# Altium schematic sheet MCU.SchDoc
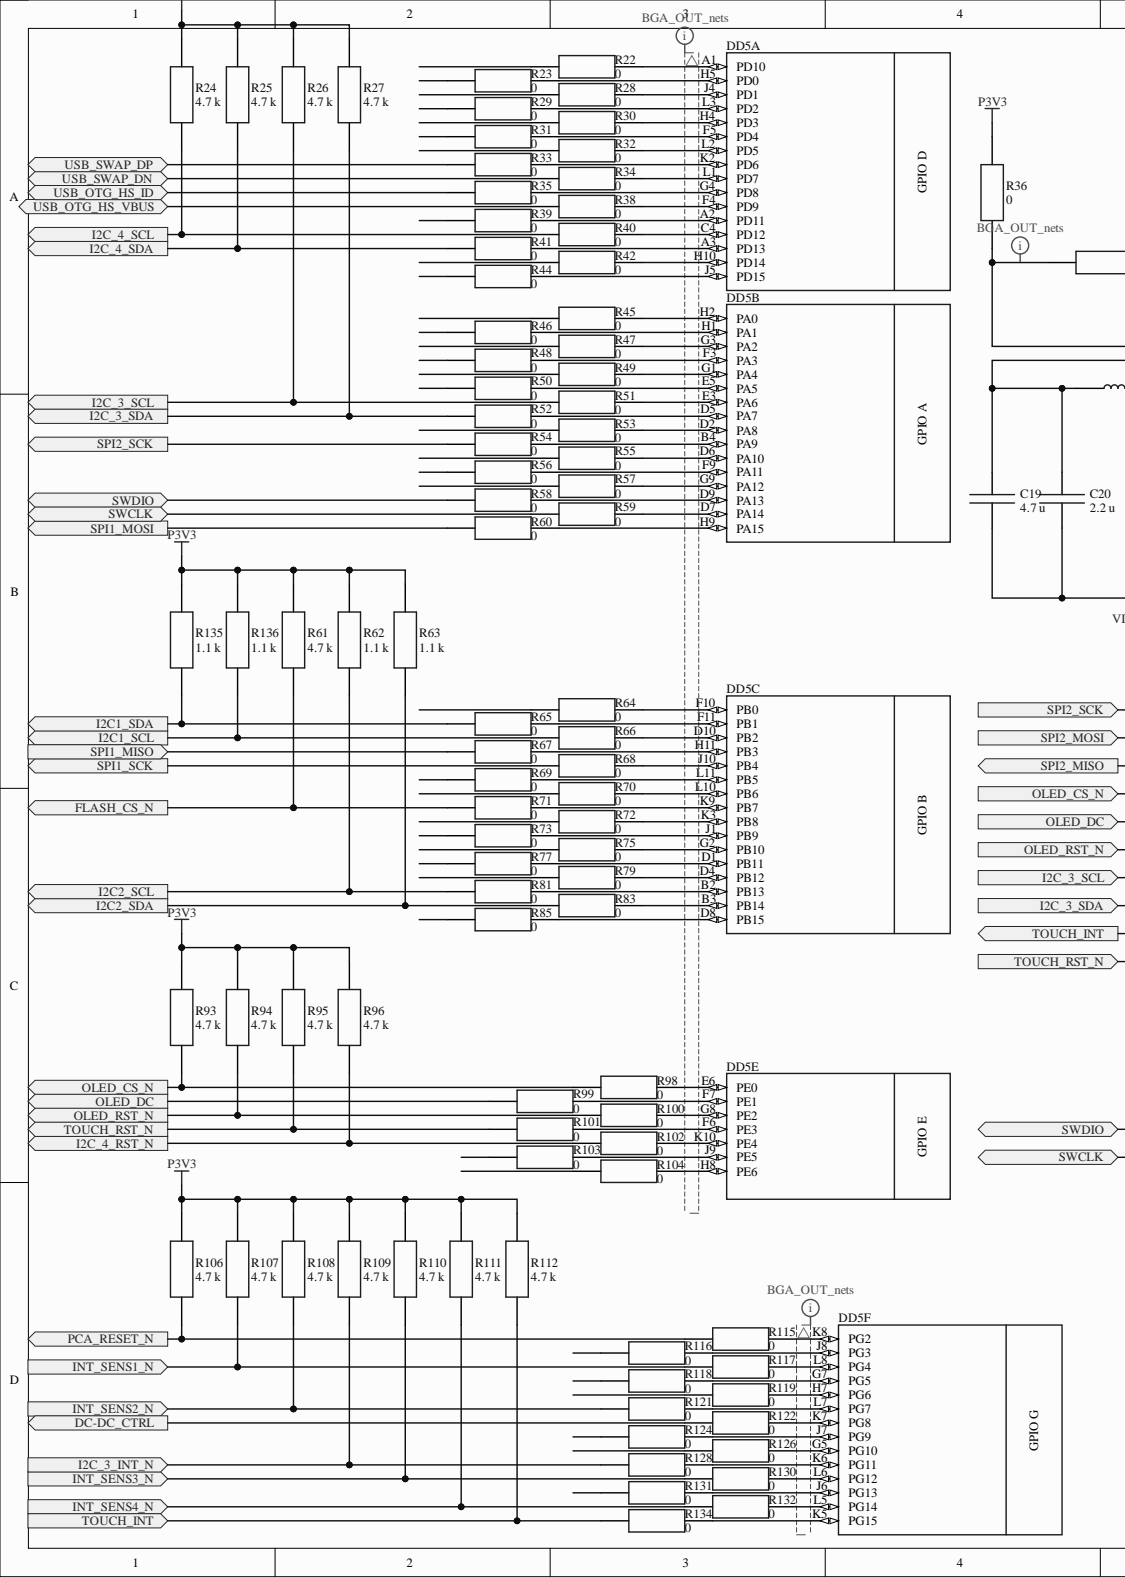
[diagram p0: MCU.SchDoc, left]
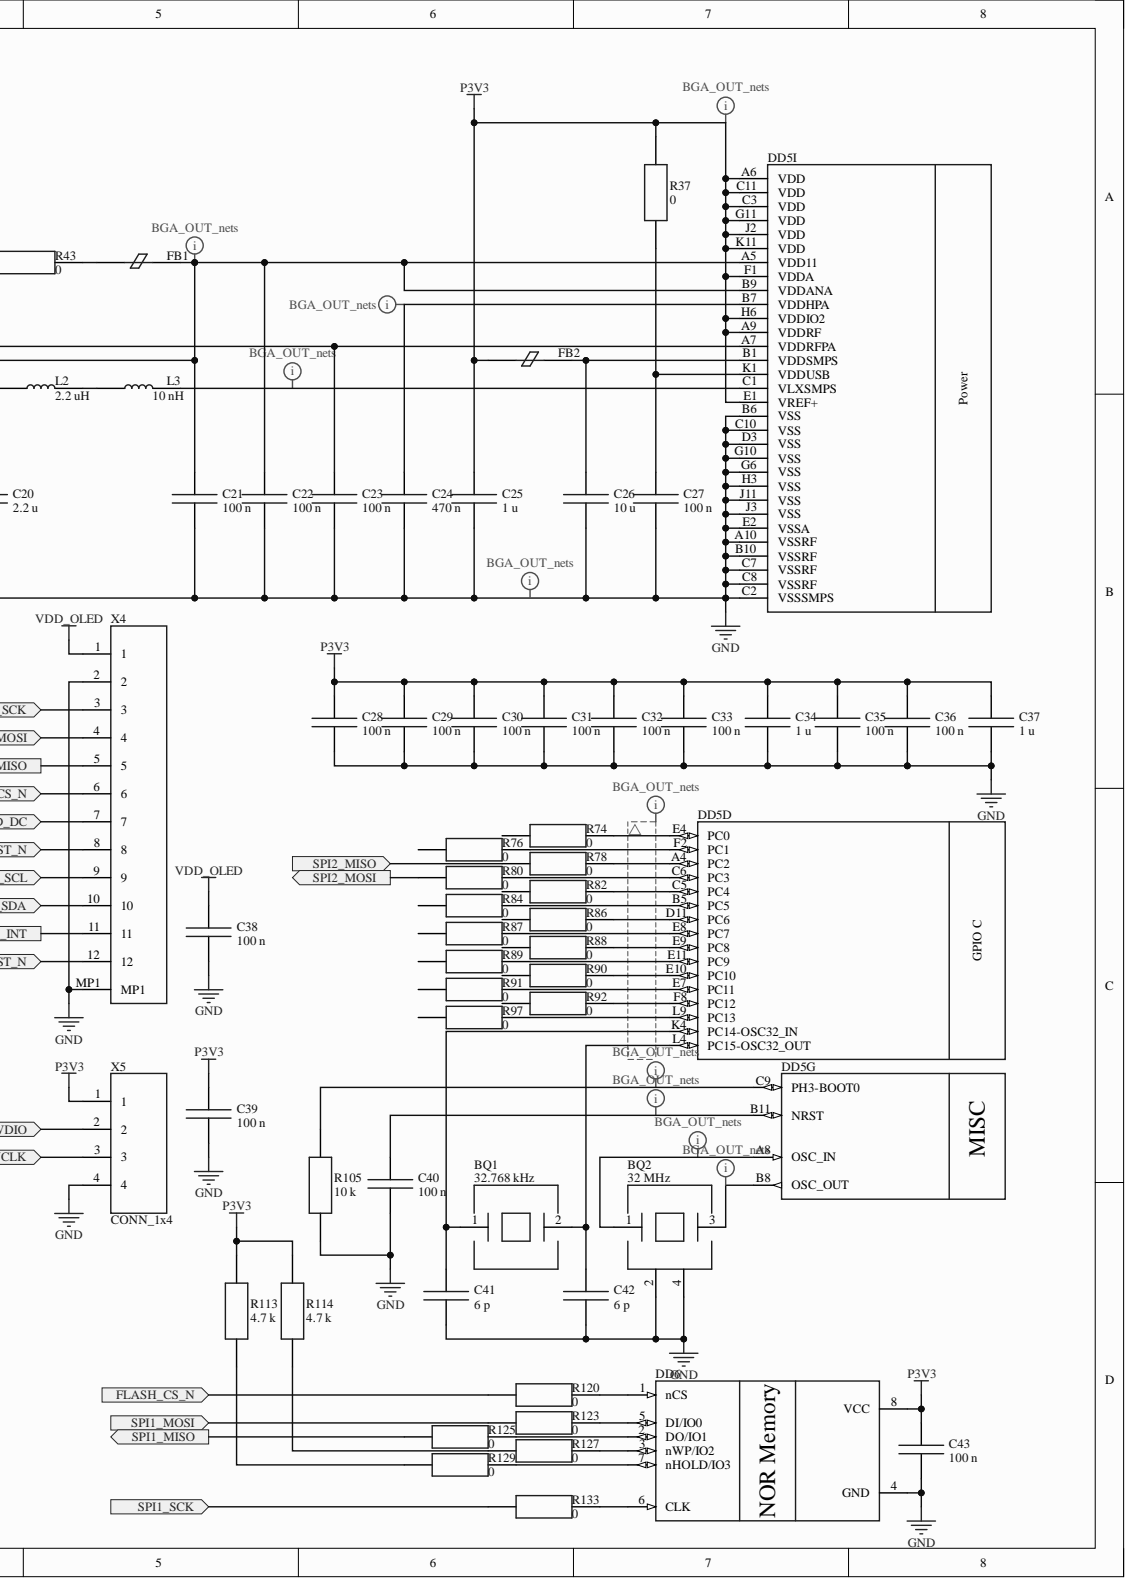
[diagram p1: MCU.SchDoc, right]

## Parts
BQ1  32.768 kHz  [BQ_SMD1610-2P]
BQ2  32 MHz  [BQ_SMD1612-4P]
C19  4.7 u  [C0402]
C20  2.2 u  [C0402]
C21  100 n  [C0402]
C22  100 n  [C0402]
C23  100 n  [C0402]
C24  470 n  [C0402]
C25  1 u  [C0402]
C26  10 u  [C0603]
C27  100 n  [C0402]
C28  100 n  [C0402]
C29  100 n  [C0402]
C30  100 n  [C0402]
C31  100 n  [C0402]
C32  100 n  [C0402]
C33  100 n  [C0402]
C34  1 u  [C0402]
C35  100 n  [C0402]
C36  100 n  [C0402]
C37  1 u  [C0402]
C38  100 n  [C0402]
C39  100 n  [C0402]
C40  100 n  [C0402]
C41  6 p  [C0402]
C42  6 p  [C0402]
C43  100 n  [C0402]
DD5  STM32WBA65PII6  [UFBGA-121_6x6_P0.5]  (value not drawn)  (parts A,B,C,D,E,F,G,I)
DD6  W25Q64JVSSIQ  [SOIC-8_5.28x5.28_P1.27]  (value not drawn)
FB1  (no value)  [FB0603]
FB2  (no value)  [FB0603]
L2  2.2 uH  [IND0805]
L3  10 nH  [IND0402]
R22  0  [R0402]
R23  0  [R0402]
R24  4.7 k  [R0402]
R25  4.7 k  [R0402]
R26  4.7 k  [R0402]
R27  4.7 k  [R0402]
R28  0  [R0402]
R29  0  [R0402]
R30  0  [R0402]
R31  0  [R0402]
R32  0  [R0402]
R33  0  [R0402]
R34  0  [R0402]
R35  0  [R0402]
R36  0  [R0402]
R37  0  [R0402]
R38  0  [R0402]
R39  0  [R0402]
R40  0  [R0402]
R41  0  [R0402]
R42  0  [R0402]
R43  0  [R0402]
R44  0  [R0402]
R45  0  [R0402]
R46  0  [R0402]
R47  0  [R0402]
R48  0  [R0402]
R49  0  [R0402]
R50  0  [R0402]
R51  0  [R0402]
R52  0  [R0402]
R53  0  [R0402]
R54  0  [R0402]
R55  0  [R0402]
R56  0  [R0402]
R57  0  [R0402]
R58  0  [R0402]
R59  0  [R0402]
R60  0  [R0402]
R61  4.7 k  [R0402]
R62  1.1 k  [R0402]
R63  1.1 k  [R0402]
R64  0  [R0402]
R65  0  [R0402]
R66  0  [R0402]
R67  0  [R0402]
R68  0  [R0402]
R69  0  [R0402]
R70  0  [R0402]
R71  0  [R0402]
R72  0  [R0402]
R73  0  [R0402]
R74  0  [R0402]
R75  0  [R0402]
R76  0  [R0402]
R77  0  [R0402]
R78  0  [R0402]
R79  0  [R0402]
R80  0  [R0402]
R81  0  [R0402]
R82  0  [R0402]
R83  0  [R0402]
R84  0  [R0402]
R85  0  [R0402]
R86  0  [R0402]
R87  0  [R0402]
R88  0  [R0402]
R89  0  [R0402]
R90  0  [R0402]
R91  0  [R0402]
R92  0  [R0402]
R93  4.7 k  [R0402]
R94  4.7 k  [R0402]
R95  4.7 k  [R0402]
R96  4.7 k  [R0402]
R97  0  [R0402]
R98  0  [R0402]
R99  0  [R0402]
R100  0  [R0402]
R101  0  [R0402]
R102  0  [R0402]
R103  0  [R0402]
R104  0  [R0402]
R105  10 k  [R0402]
R106  4.7 k  [R0402]
R107  4.7 k  [R0402]
R108  4.7 k  [R0402]
R109  4.7 k  [R0402]
R110  4.7 k  [R0402]
R111  4.7 k  [R0402]
R112  4.7 k  [R0402]
R113  4.7 k  [R0402]
R114  4.7 k  [R0402]
R115  0  [R0402]
R116  0  [R0402]
R117  0  [R0402]
R118  0  [R0402]
R119  0  [R0402]
R120  0  [R0402]
R121  0  [R0402]
R122  0  [R0402]
R123  0  [R0402]
R124  0  [R0402]
R125  0  [R0402]
R126  0  [R0402]
R127  0  [R0402]
R128  0  [R0402]
R129  0  [R0402]
R130  0  [R0402]
R131  0  [R0402]
R132  0  [R0402]
R133  0  [R0402]
R134  0  [R0402]
R135  1.1 k  [R0402]
R136  1.1 k  [R0402]
X4  SM12B-GHS-TB(LF)(SN)  [JST_SM12B-GHS-TB_LF_SN]  (value not drawn)
X5  CONN_1x4  [DS1021-1x4]

## Ports
DC-DC_CTRL, FLASH_CS_N, I2C1_SCL, I2C1_SDA, I2C2_SCL, I2C2_SDA, I2C_3_INT_N, I2C_3_SCL, I2C_3_SDA, I2C_4_RST_N, I2C_4_SCL, I2C_4_SDA, INT_SENS1_N, INT_SENS2_N, INT_SENS3_N, INT_SENS4_N, OLED_CS_N, OLED_DC, OLED_RST_N, PCA_RESET_N, SPI1_MISO, SPI1_MOSI, SPI1_SCK, SPI2_MISO, SPI2_MOSI, SPI2_SCK, SWCLK, SWDIO, TOUCH_INT, TOUCH_RST_N, USB_OTG_HS_ID, USB_OTG_HS_VBUS, USB_SWAP_DN, USB_SWAP_DP

## Nets
GND: BQ2.2 BQ2.4 C19.2 C20.2 C21.2 C22.2 C23.2 C24.2 C25.2 C26.2 C27.2 C28.2 C29.2 C30.2 C31.2 C32.2 C33.2 C34.2 C35.2 C36.2 C37.2 C38.2 C39.2 C40.2 C41.2 C42.2 C43.2 DD5.A10(VSSRF) DD5.B6(VSS) DD5.B10(VSSRF) DD5.C2(VSSSMPS) DD5.C7(VSSRF) DD5.C8(VSSRF) DD5.C10(VSS) DD5.D3(VSS) DD5.E2(VSSA) DD5.G6(VSS) DD5.G10(VSS) DD5.H3(VSS) DD5.J3(VSS) DD5.J11(VSS) DD6.4(GND) R105.1 X4.2 X4.MP1 X5.4
P3V3: C25.1 C28.1 C29.1 C30.1 C31.1 C32.1 C33.1 C34.1 C35.1 C36.1 C37.1 C39.1 C43.1 DD5.A6(VDD) DD5.A9(VDDRF) DD5.C3(VDD) DD5.C11(VDD) DD5.E1(VREF+) DD5.F1(VDDA) DD5.G11(VDD) DD5.H6(VDDIO2) DD5.J2(VDD) DD5.K11(VDD) DD6.8(VCC) FB2.1 R24.2 R25.2 R26.2 R27.2 R36.2 R37.2 R61.2 R62.2 R63.2 R93.2 R94.2 R95.2 R96.2 R106.2 R107.2 R108.2 R109.2 R110.2 R111.2 R112.2 R113.2 R114.2 R135.2 R136.2 X5.1
VDD_OLED: C38.1 X4.1
DC-DC_CTRL: R122.1 ; port DC-DC_CTRL
FLASH_CS_N: R61.1 R71.1 R120.1 ; port FLASH_CS_N
I2C1_SCL: R66.1 R136.1 ; port I2C1_SCL
I2C1_SDA: R65.1 R135.1 ; port I2C1_SDA
I2C2_SCL: R62.1 R81.1 ; port I2C2_SCL
I2C2_SDA: R63.1 R83.1 ; port I2C2_SDA
I2C_3_INT_N: R109.1 R128.1 ; port I2C_3_INT_N
I2C_3_SCL: R26.1 R51.1 X4.9 ; port I2C_3_SCL
I2C_3_SDA: R27.1 R52.1 X4.10 ; port I2C_3_SDA
I2C_4_RST_N: R96.1 R102.1 ; port I2C_4_RST_N
I2C_4_SCL: R24.1 R40.1 ; port I2C_4_SCL
I2C_4_SDA: R25.1 R41.1 ; port I2C_4_SDA
INT_SENS1_N: R107.1 R117.1 ; port INT_SENS1_N
INT_SENS2_N: R108.1 R121.1 ; port INT_SENS2_N
INT_SENS3_N: R110.1 R130.1 ; port INT_SENS3_N
INT_SENS4_N: R111.1 R132.1 ; port INT_SENS4_N
OLED_CS_N: R93.1 R98.1 X4.6 ; port OLED_CS_N
OLED_DC: R99.1 X4.7 ; port OLED_DC
OLED_RST_N: R94.1 R100.1 X4.8 ; port OLED_RST_N
PCA_RESET_N: R106.1 R115.1 ; port PCA_RESET_N
SPI1_MISO: R67.1 R125.1 ; port SPI1_MISO
SPI1_MOSI: R60.1 R123.1 ; port SPI1_MOSI
SPI1_SCK: R68.1 R133.1 ; port SPI1_SCK
SPI2_MISO: R78.1 X4.5 ; port SPI2_MISO
SPI2_MOSI: R80.1 X4.4 ; port SPI2_MOSI
SPI2_SCK: R54.1 X4.3 ; port SPI2_SCK
SWCLK: R59.1 X5.3 ; port SWCLK
SWDIO: R58.1 X5.2 ; port SWDIO
TOUCH_INT: R112.1 R134.1 X4.11 ; port TOUCH_INT
TOUCH_RST_N: R95.1 R101.1 X4.12 ; port TOUCH_RST_N
USB_OTG_HS_ID: R35.1 ; port USB_OTG_HS_ID
USB_OTG_HS_VBUS: R38.1 ; port USB_OTG_HS_VBUS
USB_SWAP_DN: R34.1 ; port USB_SWAP_DN
USB_SWAP_DP: R33.1 ; port USB_SWAP_DP
NetBQ1_1: BQ1.1 C41.1 DD5.K4(PC14-OSC32_IN)
NetBQ1_2: BQ1.2 C42.1 DD5.L4(PC15-OSC32_OUT)
NetBQ2_1: BQ2.1 DD5.A8(OSC_IN)
NetBQ2_3: BQ2.3 DD5.B8(OSC_OUT)
NetC19_1: C19.1 C20.1 C21.1 C22.1 DD5.A5(VDD11) DD5.B9(VDDANA) FB1.2 L2.1
NetC23_1: C23.1 DD5.A7(VDDRFPA) R36.1 R43.1
NetC24_1: C24.1 DD5.B7(VDDHPA)
NetC26_1: C26.1 DD5.B1(VDDSMPS) FB2.2
NetC27_1: C27.1 DD5.K1(VDDUSB) R37.1
NetC40_1: C40.1 DD5.B11(NRST)
NetDD5_A1: DD5.A1(PD10) R22.2
NetDD5_A2: DD5.A2(PD11) R39.2
NetDD5_A3: DD5.A3(PD13) R41.2
NetDD5_A4: DD5.A4(PC2) R78.2
NetDD5_B2: DD5.B2(PB13) R81.2
NetDD5_B3: DD5.B3(PB14) R83.2
NetDD5_B4: DD5.B4(PA9) R54.2
NetDD5_B5: DD5.B5(PC5) R84.2
NetDD5_C1: DD5.C1(VLXSMPS) L3.2
NetDD5_C4: DD5.C4(PD12) R40.2
NetDD5_C5: DD5.C5(PC4) R82.2
NetDD5_C6: DD5.C6(PC3) R80.2
NetDD5_C9: DD5.C9(PH3-BOOT0) R105.2
NetDD5_D1: DD5.D1(PB11) R77.2
NetDD5_D2: DD5.D2(PA8) R53.2
NetDD5_D4: DD5.D4(PB12) R79.2
NetDD5_D5: DD5.D5(PA7) R52.2
NetDD5_D6: DD5.D6(PA10) R55.2
NetDD5_D7: DD5.D7(PA14) R59.2
NetDD5_D8: DD5.D8(PB15) R85.2
NetDD5_D9: DD5.D9(PA13) R58.2
NetDD5_D10: DD5.D10(PB2) R66.2
NetDD5_D11: DD5.D11(PC6) R86.2
NetDD5_E3: DD5.E3(PA6) R51.2
NetDD5_E4: DD5.E4(PC0) R74.2
NetDD5_E5: DD5.E5(PA5) R50.2
NetDD5_E6: DD5.E6(PE0) R98.2
NetDD5_E7: DD5.E7(PC11) R91.2
NetDD5_E8: DD5.E8(PC7) R87.2
NetDD5_E9: DD5.E9(PC8) R88.2
NetDD5_E10: DD5.E10(PC10) R90.2
NetDD5_E11: DD5.E11(PC9) R89.2
NetDD5_F2: DD5.F2(PC1) R76.2
NetDD5_F3: DD5.F3(PA3) R48.2
NetDD5_F4: DD5.F4(PD9) R38.2
NetDD5_F5: DD5.F5(PD4) R31.2
NetDD5_F6: DD5.F6(PE3) R101.2
NetDD5_F7: DD5.F7(PE1) R99.2
NetDD5_F8: DD5.F8(PC12) R92.2
NetDD5_F9: DD5.F9(PA11) R56.2
NetDD5_F10: DD5.F10(PB0) R64.2
NetDD5_F11: DD5.F11(PB1) R65.2
NetDD5_G1: DD5.G1(PA4) R49.2
NetDD5_G2: DD5.G2(PB10) R75.2
NetDD5_G3: DD5.G3(PA2) R47.2
NetDD5_G4: DD5.G4(PD8) R35.2
NetDD5_G5: DD5.G5(PG10) R126.2
NetDD5_G7: DD5.G7(PG5) R118.2
NetDD5_G8: DD5.G8(PE2) R100.2
NetDD5_G9: DD5.G9(PA12) R57.2
NetDD5_H1: DD5.H1(PA1) R46.2
NetDD5_H2: DD5.H2(PA0) R45.2
NetDD5_H4: DD5.H4(PD3) R30.2
NetDD5_H5: DD5.H5(PD0) R23.2
NetDD5_H7: DD5.H7(PG6) R119.2
NetDD5_H8: DD5.H8(PE6) R104.2
NetDD5_H9: DD5.H9(PA15) R60.2
NetDD5_H10: DD5.H10(PD14) R42.2
NetDD5_H11: DD5.H11(PB3) R67.2
NetDD5_J1: DD5.J1(PB9) R73.2
NetDD5_J4: DD5.J4(PD1) R28.2
NetDD5_J5: DD5.J5(PD15) R44.2
NetDD5_J6: DD5.J6(PG13) R131.2
NetDD5_J7: DD5.J7(PG9) R124.2
NetDD5_J8: DD5.J8(PG3) R116.2
NetDD5_J9: DD5.J9(PE5) R103.2
NetDD5_J10: DD5.J10(PB4) R68.2
NetDD5_K2: DD5.K2(PD6) R33.2
NetDD5_K3: DD5.K3(PB8) R72.2
NetDD5_K5: DD5.K5(PG15) R134.2
NetDD5_K6: DD5.K6(PG11) R128.2
NetDD5_K7: DD5.K7(PG8) R122.2
NetDD5_K8: DD5.K8(PG2) R115.2
NetDD5_K9: DD5.K9(PB7) R71.2
NetDD5_K10: DD5.K10(PE4) R102.2
NetDD5_L1: DD5.L1(PD7) R34.2
NetDD5_L2: DD5.L2(PD5) R32.2
NetDD5_L3: DD5.L3(PD2) R29.2
NetDD5_L5: DD5.L5(PG14) R132.2
NetDD5_L6: DD5.L6(PG12) R130.2
NetDD5_L7: DD5.L7(PG7) R121.2
NetDD5_L8: DD5.L8(PG4) R117.2
NetDD5_L9: DD5.L9(PC13) R97.2
NetDD5_L10: DD5.L10(PB6) R70.2
NetDD5_L11: DD5.L11(PB5) R69.2
NetDD6_1: DD6.1(nCS) R120.2
NetDD6_2: DD6.2(DO/IO1) R125.2
NetDD6_3: DD6.3(nWP/IO2) R127.2
NetDD6_5: DD6.5(DI/IO0) R123.2
NetDD6_6: DD6.6(CLK) R133.2
NetDD6_7: DD6.7(nHOLD/IO3) R129.2
NetFB1_1: FB1.1 R43.2
NetL2_2: L2.2 L3.1
NetR113_1: R113.1 R129.1
NetR114_1: R114.1 R127.1

Not connected: R22 1; R23 1; R28 1; R29 1; R30 1; R31 1; R32 1; R39 1; R42 1; R44 1; R45 1; R46 1; R47 1; R48 1; R49 1; R50 1; R53 1; R55 1; R56 1; R57 1; R64 1; R69 1; R70 1; R72 1; R73 1; R74 1; R75 1; R76 1; R77 1; R79 1; R82 1; R84 1; R85 1; R86 1; R87 1; R88 1; R89 1; R90 1; R91 1; R92 1; R97 1; R103 1; R104 1; R116 1; R118 1; R119 1; R124 1; R126 1; R131 1

## Library data of the parts
BQ1 = NX1610SE-32.768KHZ-EXS00A-MU01501: XTAL, NX1610SE-32.768KHZ-EXS00A-MU01501, 32.768 kHz, CL 6 pF, 2P, 1.6x1.0 mm; Manufacturer: NDK; Database Table Name: BQ_Crystal_Oscillator; Device Type: Crystal; Frequency Tolerance: +/-20 ppm; ID: BQ_000002; Load Capacitance: 6 pF; Component Kind: Standard; ComponentLink1Description: Manufacturer documentation; ComponentLink1URL: https://www.ndk.com/en/products/upload/lineup/pdf/NDKX01-00011_en.pdf; Frequency: 32.768 kHz; Library Name: Database_Libs1.DbLib; Manufacturer Part Number: NX1610SE-32.768KHZ-EXS00A-MU01501; Mounting Technology: SMD; Package: 1.6x1.0 mm; Part Number: NX1610SE-32.768KHZ-EXS00A-MU01501
BQ2 = NX1612SA-32MHZ-EXS00A-CS09166: XTAL, NX1612SA-32MHZ-EXS00A-CS09166, 32 MHz, CL 8 pF, 4P, 1.6x1.2 mm; Manufacturer: NDK; Database Table Name: BQ_Crystal_Oscillator; Device Type: Crystal; Frequency Tolerance: +/-10 ppm; ID: BQ_000003; Load Capacitance: 8 pF; Component Kind: Standard; ComponentLink1Description: ST reference design, AN5948; ComponentLink1URL: https://www.st.com/resource/en/application_note/an5948-how-to-develop-rf-hardware-using-stm32wba-mcus-stmicroelectronics.pdf; Frequency: 32 MHz; Library Name: Database_Libs1.DbLib; Manufacturer Part Number: NX1612SA-32MHZ-EXS00A-CS09166; Mounting Technology: SMD; Package: 1.6x1.2 mm; Part Number: NX1612SA-32MHZ-EXS00A-CS09166
C19 = C0402 X7R 4.7 uF 10% 25 V: MLCC 0402, X7R, 4.7 uF, 10%, 16 V; Capacitance: 4.7 uF; Database Table Name: C_Capacitor; ID: C_001115; Voltage Rating: 16 V; Component Kind: Standard; Dielectric: X7R; Library Name: Database_Libs1.DbLib; Mounting Technology: SMD; Package: C0402; Part Number: C0402 X7R 4.7 uF 10% 25 V; Temperature Range: -55...+125 C; Tolerance: 10%
C20 = C0402 X7R 2.2 uF 10% 16 V: MLCC 0402, X7R, 2.2 uF, 10%, 16 V; Capacitance: 2.2 uF; Database Table Name: C_Capacitor; ID: C_001116; Voltage Rating: 16 V; Component Kind: Standard; Dielectric: X7R; Library Name: Database_Libs1.DbLib; Mounting Technology: SMD; Package: C0402; Part Number: C0402 X7R 2.2 uF 10% 16 V; Temperature Range: -55...+125 C; Tolerance: 10%
C21-C23 C27-C33 C35 C36 C38-C40 C43 = C0402 X7R 100 nF 10% 16 V: MLCC 0402, X7R, 100 nF, 10%, 16 V; Capacitance: 100 nF; Database Table Name: C_Capacitor; ID: C_000136; Voltage Rating: 16 V; Component Kind: Standard; Dielectric: X7R; Library Name: Database_Libs1.DbLib; Mounting Technology: SMD; Package: C0402; Part Number: C0402 X7R 100 nF 10% 16 V; Temperature Range: -55...+125 C; Tolerance: 10%
C24 = C0402 X7R 470 nF 10% 16 V: MLCC 0402, X7R, 470 nF, 10%, 16 V; Capacitance: 470 nF; Database Table Name: C_Capacitor; ID: C_000144; Voltage Rating: 16 V; Component Kind: Standard; Dielectric: X7R; Library Name: Database_Libs1.DbLib; Mounting Technology: SMD; Package: C0402; Part Number: C0402 X7R 470 nF 10% 16 V; Temperature Range: -55...+125 C; Tolerance: 10%
C25 C34 C37 = C0402 X7R 1 uF 10% 16 V: MLCC 0402, X7R, 1 uF, 10%, 16 V; Capacitance: 1 uF; Database Table Name: C_Capacitor; ID: C_000148; Voltage Rating: 16 V; Component Kind: Standard; Dielectric: X7R; Library Name: Database_Libs1.DbLib; Mounting Technology: SMD; Package: C0402; Part Number: C0402 X7R 1 uF 10% 16 V; Temperature Range: -55...+125 C; Tolerance: 10%
C26 = C0603 X7R 10 uF 10% 16 V: MLCC 0603, X7R, 10 uF, 10%, 16 V; Capacitance: 10 uF; Database Table Name: C_Capacitor; ID: C_001120; Voltage Rating: 16 V; Component Kind: Standard; Dielectric: X7R; Library Name: Database_Libs1.DbLib; Mounting Technology: SMD; Package: C0603; Part Number: C0603 X7R 10 uF 10% 16 V; Temperature Range: -55...+125 C; Tolerance: 10%
C41 C42 = C0402 NPO 6 pF ±0.25 pF 50 V: MLCC 0402, NP0, 6 pF, ±0.25 pF, 50 V; Capacitance: 6 pF; Database Table Name: C_Capacitor; ID: C_001121; Component Kind: Standard; Library Name: Database_Libs1.DbLib; Mounting Technology: SMD; Package: C0402; Part Number: C0402 NPO 6 pF ±0.25 pF 50 V; Simulation: ±0.25 pF
DD5 = STM32WBA65PII6: IC, WIRELESS MCU, STM32WBA65PII6, CORTEX-M33, FPU, 100MHz, FLASH 2MB, RAM 512KB, BLE/IEEE 802.15.4, UFBGA121, 121P, P0.5mm, SMT; Manufacturer: STMicroelectronics; Architecture: 32-bit Armv8-M; Core: Arm Cortex-M33 with FPU; Database Table Name: IC_MCU; GPIO Count: 86; ID: ICMCU_000007; Supply Voltage: VDD 1.71-3.6 V; see supply-domain conditions; Component Kind: Standard; ComponentLink1Description: ST datasheet DS14736 Rev 3; ComponentLink1URL: https://www.st.com/resource/en/datasheet/stm32wba65pi.pdf; Flash: 2 MB; Library Name: Database_Libs1.DbLib; Manufacturer Part Number: STM32WBA65PII6; Max Frequency: 100 MHz; Mounting Technology: SMT; Package: UFBGA121 6x6 mm, pitch 0.5 mm; Part Number: STM32WBA65PII6; RAM: 512 KB
DD6 = W25Q64JVSSIQ: IC, NOR FLASH, W25Q64JVSSIQ, 64Mbit, SPI/DUAL SPI/QUAD SPI, 2.7-3.6V, SOIC8 208MIL, 8P, P1.27mm; Manufacturer: Winbond; Database Table Name: IC_Memory; ID: ICMEM_000001; Component Kind: Standard; ComponentLink1Description: Datasheet; ComponentLink1URL: https://docs.rs-online.com/53b3/0900766b8162304e.pdf; Library Name: Database_Libs1.DbLib; Manufacturer Part Number: W25Q64JVSSIQ; Mounting Technology: SMT; Package: SOIC-8; Part Number: W25Q64JVSSIQ
FB1 FB2 = FBMH1608HM470-T: FERRITE BEAD, FBMH1608HM470-T, 47 Ohm @ 100 MHz, 25%, 3.5 A, DCR 20 mOhm max, 0603; Manufacturer: Taiyo Yuden; Database Table Name: FB_Ferrite_Bead; ID: FB_000001; Impedance: 47 Ohm; Rated Current: 3.5 A; Test Frequency: 100 MHz; Component Kind: Standard; ComponentLink1Description: Manufacturer datasheet; ComponentLink1URL: https://mm.digikey.com/Volume0/opasdata/d220001/medias/docus/3530/FBMH1608HM470-T_SS.pdf; DCR: 20 mOhm max; Library Name: Database_Libs1.DbLib; Manufacturer Part Number: FBMH1608HM470-T; Mounting Technology: SMD; Package: 0603; Part Number: FBMH1608HM470-T
L2 = LQM21PN2R2MGHL: INDUCTOR, POWER, LQM21PN2R2MGHL, 2.2 uH, 20%, 0.45 A Isat, 1.3 A Itemp, DCR 156 mOhm max, 0805; Manufacturer: Murata; Database Table Name: L_Inductor; ID: L_000001; Inductor Type: Multilayer power, shielded; Rated Current: 1.3 A; Saturation Current: 0.45 A; SRF: 40 MHz min; Component Kind: Standard; ComponentLink1Description: Manufacturer datasheet; ComponentLink1URL: https://cdn.promelec.ru/upload/items/2024/02/21/LQM21PN2R2MGHL.pdf; DCR: 156 mOhm max; Inductance: 2.2 uH; Library Name: Database_Libs1.DbLib; Manufacturer Part Number: LQM21PN2R2MGHL; Mounting Technology: SMD; Package: 0805; Part Number: LQM21PN2R2MGHL; Tolerance: 20%
L3 = LQG15HS10NJ02D: INDUCTOR, RF, LQG15HS10NJ02D, 10 nH, 5%, 500 mA, DCR 260 mOhm max, 0402; Manufacturer: Murata; Database Table Name: L_Inductor; ID: L_000002; Inductor Type: Multilayer RF; Rated Current: 500 mA; SRF: 3.4 GHz; Component Kind: Standard; ComponentLink1Description: Manufacturer datasheet; ComponentLink1URL: https://www.mantech.co.za/Datasheets/Products/LQG15HS_MURATA.pdf; DCR: 260 mOhm max; Inductance: 10 nH; Library Name: Database_Libs1.DbLib; Manufacturer Part Number: LQG15HS10NJ02D; Mounting Technology: SMD; Package: 0402; Part Number: LQG15HS10NJ02D; Tolerance: 5%
R22 R23 R28-R60 R64-R92 R97-R104 R115-R134 = R0402 0 Ohm 1%: SMD resistor 0402, 0 Ohm, 1%; Database Table Name: R_Resistor; ID: R_000677; Component Kind: Standard; Library Name: Database_Libs1.DbLib; Mounting Technology: SMD; Package: R0402; Part Number: R0402 0 Ohm 1%; Resistance: 0; Technology: Thick Film; Tolerance: 1%
R24-R27 R61 R93-R96 R106-R114 = R0402 4.7 kOhm 1%: SMD resistor 0402, 4.7 kOhm, 1%; Database Table Name: R_Resistor; ID: R_000089; Component Kind: Standard; Library Name: Database_Libs1.DbLib; Mounting Technology: SMD; Package: R0402; Part Number: R0402 4.7 kOhm 1%; Resistance: 4.7 kOhm; Technology: Thick Film; Tolerance: 1%
R62 R63 R135 R136 = R0402 1.1 kOhm 1%: SMD resistor 0402, 1.1 kOhm, 1%; Database Table Name: R_Resistor; ID: R_000074; Component Kind: Standard; Library Name: Database_Libs1.DbLib; Mounting Technology: SMD; Package: R0402; Part Number: R0402 1.1 kOhm 1%; Resistance: 1.1 kOhm; Technology: Thick Film; Tolerance: 1%
R105 = R0402 10 kOhm 1%: SMD resistor 0402, 10 kOhm, 1%; Database Table Name: R_Resistor; ID: R_000097; Resistance: 10 kOhm; Component Kind: Standard; Library Name: Database_Libs1.DbLib; Mounting Technology: SMD; Package: R0402; Part Number: R0402 10 kOhm 1%; Technology: Thick Film; Tolerance: 1%
X4 = SM12B-GHS-TB(LF)(SN): CONN, WIRE-TO-BOARD, SM12B-GHS-TB(LF)(SN), JST GH, 12P, P1.25, MALE, RA, SMD; Connector Type: Wire-to-board header; Contact Count: 12; Current Rating: 1 A; Database Table Name: X_Connector; ID: X_000012; Pitch: 1.25 mm; Row Count: 1; Voltage Rating: 50 V; Component Kind: Standard; ComponentLink1Description: Manufacturer datasheet; ComponentLink1URL: https://www.jst-mfg.com/product/pdf/eng/eGH.pdf; Gender: Male; Library Name: Database_Libs1.DbLib; Manufacturer: JST; Manufacturer Part Number: SM12B-GHS-TB(LF)(SN); Mounting Technology: SMD; Package: JST GH, side entry; Part Number: SM12B-GHS-TB(LF)(SN)
X5 = DS1021-1x4: CONN, PLS, DS1021-1x4, 4P, P2.54, BLACK; Database Table Name: X_Connector; ID: X_000003; Component Kind: Standard; Library Name: Database_Libs1.DbLib; Part Number: DS1021-1x4
